# Altium schematic sheet Power.SchDoc(Power)
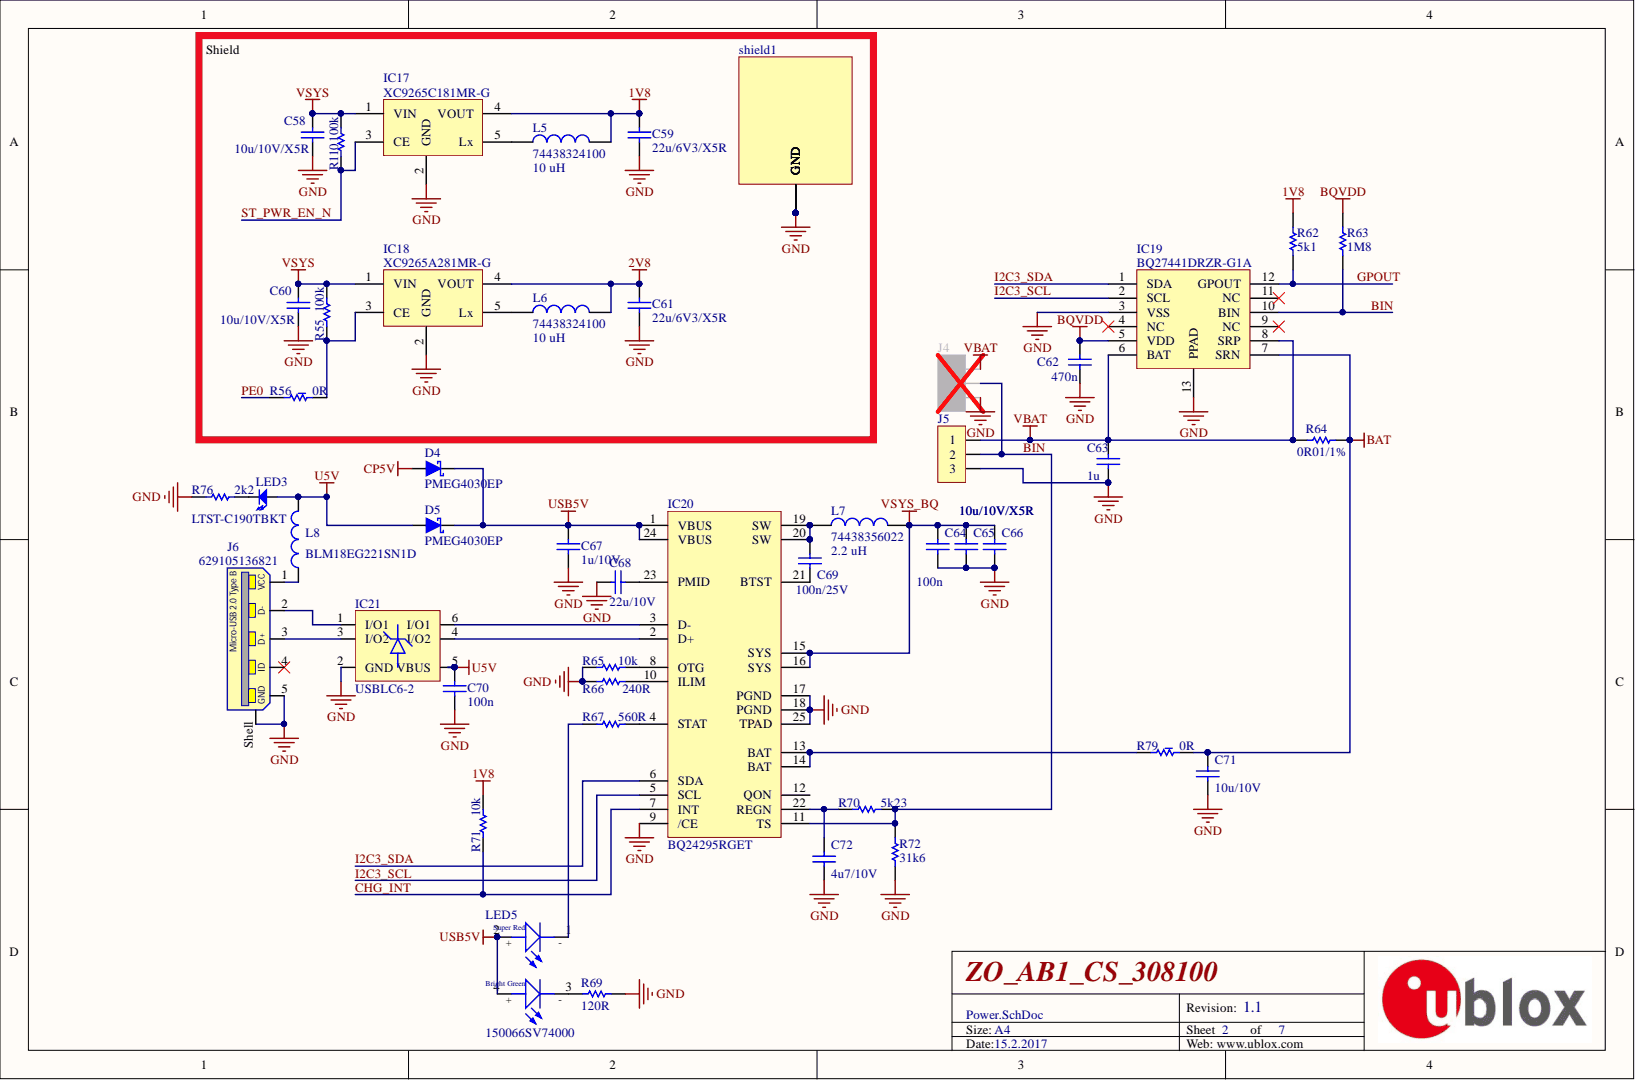
[diagram p0: Power.SchDoc]

## Parts
C58  10u/10V/X5R  [C0603]
C59  22u/6V3/X5R  [C0603]
C60  10u/10V/X5R  [C0603]
C61  22u/6V3/X5R  [C0603]
C62  470n  [C0603]
C63  1u  [C0603]
C64  100n  [C0402]
C65  10u/10V/X5R  [C0603]
C66  10u/10V/X5R  [C0603]
C67  1u/10V  [C0603]
C68  22u/10V  [C0805]
C69  100n/25V  [C0603]
C70  100n  [C0402]
C71  10u/10V  [C0805]
C72  4u7/10V  [C0603]
D4  PMEG4030EP  [SOD128]
D5  PMEG4030EP  [SOD128]
IC17  XC9265C181MR-G  [SOT23-5L]
IC18  XC9265A281MR-G  [SOT23-5L]
IC19  BQ27441DRZR-G1A  [DRZ-12N]
IC20  BQ24295RGET  [RGE-24L]
IC21  USBLC6-2  [SOT23-6L]
J4  Molex 53048-0310  [Molex 53048-0310]  (value not drawn)
J5  Molex 22-05-7035  [Molex 22-05-7035]  (value not drawn)
J6  629105136821  [629105136821]
L5  74438324100  value 10 uH  [WE-MAPI_2512]
L6  74438324100  value 10 uH  [WE-MAPI_2512]
L7  74438356022  value 2.2 uH  [WE-MAPI_4020]
L8  BLM18EG221SN1D  [L0603]
LED3  LTST-C190TBKT  [LED0603 - BLUE]
LED5  150066SV74000  [WL-SBCW 0606]
R55  100k  [R0402]
R56  0R  [R0603pacman]
R62  5k1  [R0402]
R63  1M8  [R0402]
R64  0R01/1%  [R0603]
R65  10k  [R0402]
R66  240R  [R0402]
R67  560R  [R0402]
R69  120R  [R0402]
R70  5k23  [R0402]
R71  10k  [R0402]
R72  31k6  [R0402]
R76  2k2  [R0402]
R79  0R  [R0603pacman]
R110  100k  [R0402]
shield1  UBXH04-0000019  [UBXH04-0000019]  (value not drawn)

## Nets
1V8: C59.2 IC17.4(VOUT) L5.2 R62.2 R71.1
2V8: C61.2 IC18.4(VOUT) L6.2
BAT: C71.2 IC19.7(SRN) R64.2 R79.2
BQVDD: C62.2 IC19.5(VDD) R63.2
CP5V: D4.A
GND: C58.1 C59.1 C60.1 C61.1 C62.1 C63.1 C64.1 C65.1 C66.1 C67.1 C68.2 C70.1 C71.1 C72.1 IC17.2(GND) IC18.2(GND) IC19.3(VSS) IC19.13(PPAD) IC20.9(/CE) IC20.17(PGND) IC20.18(PGND) IC20.25(TPAD) IC21.2(GND) J4.3 J5.3 J6.5 J6.Shell R65.2 R66.2 R69.2 R72.2 R76.2 shield1.1(GND) shield1.2 shield1.3 shield1.4 shield1.5 shield1.6
U5V: C70.2 D5.A IC21.5(VBUS) L8.2 LED3.A
USB5V: C67.2 D4.C D5.C IC20.1(VBUS) IC20.24(VBUS) LED5.2 LED5.4
VBAT: C63.2 IC19.6(BAT) IC19.8(SRP) J4.1 J5.1 R64.1
VSYS: C58.2 C60.2 IC17.1(VIN) IC18.1(VIN) R55.1 R110.1
VSYS_BQ: C64.2 C65.2 C66.2 IC20.15(SYS) IC20.16(SYS) L7.2
BIN: IC19.10(BIN) IC20.11(TS) J4.2 J5.2 R63.1 R70.1 R72.1
CHG_INT: IC20.7(INT) R71.2
GPOUT: IC19.12(GPOUT) R62.1
I2C3_SCL: IC19.2(SCL) IC20.5(SCL)
I2C3_SDA: IC19.1(SDA) IC20.6(SDA)
PE0: R56.1
ST_PWR_EN_N: IC17.3(CE) R110.2
NetC68_1: C68.1 IC20.23(PMID)
NetC69_1: C69.1 IC20.21(BTST)
NetC69_2: C69.2 IC20.19(SW) IC20.20(SW) L7.1
NetC72_2: C72.2 IC20.22(REGN) R70.2
NetIC17_5: IC17.5(Lx) L5.1
NetIC18_3: IC18.3(CE) R55.2 R56.2
NetIC18_5: IC18.5(Lx) L6.1
NetIC20_2: IC20.2(D+) IC21.4(I/O2)
NetIC20_3: IC20.3(D-) IC21.6(I/O1)
NetIC20_4: IC20.4(STAT) R67.1
NetIC20_8: IC20.8(OTG) R65.1
NetIC20_10: IC20.10(ILIM) R66.1
NetIC20_13: IC20.13(BAT) IC20.14(BAT) R79.1
NetIC21_1: IC21.1(I/O1) J6.2(D-)
NetIC21_3: IC21.3(I/O2) J6.3(D+)
NetJ6_1: J6.1 L8.1
NetLED3_K: LED3.K R76.1
NetLED5_1: LED5.1 R67.2
NetLED5_3: LED5.3 R69.1

Not connected: IC19 4(NC) 9(NC) 11(NC); IC20 12(QON); J6 4(ID)

## Library data of the parts
C58-C72 = Capacitor SMD: SMD chip capacitor; Value: Xn; Component Kind: Standard; LatestRevisionDate: 26-Dec-2008; Published: 26-Dec-2008; Signal Integrity: Cap; Simulation: CAP; Library Name: BorisPassive.SchLib; Publisher: www.poscope.com
D4 D5 = D Schottky: Schottky Diode; Code_JEDEC: DO-214-AA; Component Kind: Standard; LatestRevisionDate: 17-Jul-2002; LatestRevisionNote: Re-released for DXP Platform.; PackageReference: SMB; Published: 8-Jun-2000; Signal Integrity: D Schottky; Simulation: SKYDIODE; Library Name: BorisSemi.SchLib; Publisher: Altium Limited
IC17 IC18 = XC9265C181MR-G: Ultra Low Power Synchronous Step-Down PFM DC/DC Converter; Component Kind: Standard; Library Name: BorisIC1.SchLib
IC19 = BQ27441DRZR-G1A: bq27441-G1 System-Side Impedance Track™ Fuel Gauge; Component Kind: Standard; Library Name: BorisIC1.SchLib
IC20 = BQ24295RGET: I2C Controlled 3A Single Cell USB Charger with Adjustable Voltage and 1.5A OTG; Component Kind: Standard; Library Name: BorisIC1.SchLib
IC21 = USBLC6-2: Very low capacitance ESD protection; Component Kind: Standard; Library Name: BorisIC1.SchLib
J4 = Molex 53048-0310: Molex 53048-0310  3 pole; Component Kind: Standard; Library Name: BorisCon.SchLib
J5 = Molex 22-05-7035: Molex 22-05-7035 3 pin connector; Component Kind: Standard; Library Name: BorisCon.SchLib
J6 = 629105136821: WR-COM Micro USB 2.0 SMT Type B Horizontal 5 Contacts; Component Kind: Standard; ComponentLink1Description: Manufacturer; ComponentLink1URL: http://www.we-online.de; ComponentLink2Description: Datasheet; ComponentLink2URL: http://katalog.we-online.de/em/datasheet/629105136821.pdf; Library Name: ALTIUM-WR-COM-rev16d.IntLib; PartNumber: 629105136821; Published: June 2016; Publisher: Wurth Elektronik
L5 L6 = 74438324100: WE-MAPI SMD Shielded Power Inductor, size 2512, 10 uH; RatedCurrent: 0.7 A; Component Kind: Standard; ComponentLink1Description: Manufacturer; ComponentLink1URL: http://www.we-online.de; ComponentLink2Description: Datasheet; ComponentLink2URL: http://katalog.we-online.de/pbs/datasheet/74438324100.pdf; Library Name: ALTIUM-WE-MAPI-rev16b.IntLib; PartNumber: 74438324100; PublishedDate: Nov. 2016; Size: 2512; Publisher: Wurth Elektronik
L7 = 74438356022: WE-MAPI SMD Shielded Power Inductor, size 4020, 2.2 uH; RatedCurrent: 4.7 A; Value: 2.2 uH; Component Kind: Standard; ComponentLink1Description: Manufacturer; ComponentLink1URL: http://www.we-online.de; ComponentLink2Description: Datasheet; ComponentLink2URL: http://katalog.we-online.de/pbs/datasheet/74438356022.pdf; Library Name: ALTIUM-WE-MAPI-rev16b.IntLib; PartNumber: 74438356022; PublishedDate: Nov. 2016; Size: 4020; Publisher: Wurth Elektronik
L8 = Inductor SMD: Value: XuH; Component Kind: Standard; LatestRevisionDate: 21 March 2009; Published: 21 March 2009; Library Name: BorisPassive.SchLib; Publisher: www.poscope.com
LED3 = LEDmini: Component Kind: Standard; Description: LEDmini; Library Name: BorisSemi.SchLib
LED5 = WL-SBCW 0606, 150066SV74000: WL-SBCW SMD chip LED top view bi-color waterclear, 0606; Manufacturer: Wurth Elektronik; Color: Super Red & Bright Green; Component Kind: Standard; ComponentLink1Description: Manufacturer; ComponentLink1URL: http://www.we-online.de; ComponentLink2Description: Datasheet; ComponentLink2URL: http://katalog.we-online.de/led/datasheet/150066SV74000.pdf; Created Date: March 2016; Library Name: ALTIUM-WL-SBCW-rev16a.IntLib; PartNo: 150066SV74000; Created by: Baojun Chen
R55 R62-R67 R69-R72 R76 R110 = Resistor SMD: SMD chip resistor; Value: Xk; Component Kind: Standard; LatestRevisionDate: 26-Dec-2008; Published: 26-Dec-2008; Signal Integrity: Res Semi; Simulation: RES; Library Name: BorisPassive.SchLib; Publisher: www.poscope.com
R56 R79 = Resistor Pacman SMD: SMD chip resistor with Payman for easy soldering; Value: Xk; Component Kind: Standard; LatestRevisionDate: 26-Dec-2008; Published: 26-Dec-2008; Signal Integrity: Res Semi; Simulation: RES; Library Name: BorisPassive.SchLib; Publisher: www.poscope.com
shield1 = UBXH04-0000019: Ublox Max Shield 9,1 x 9,1 mm; Component Kind: Standard; Library Name: BorisMech.SchLib
